# Altium schematic sheet PowerSubsystem.SchDoc
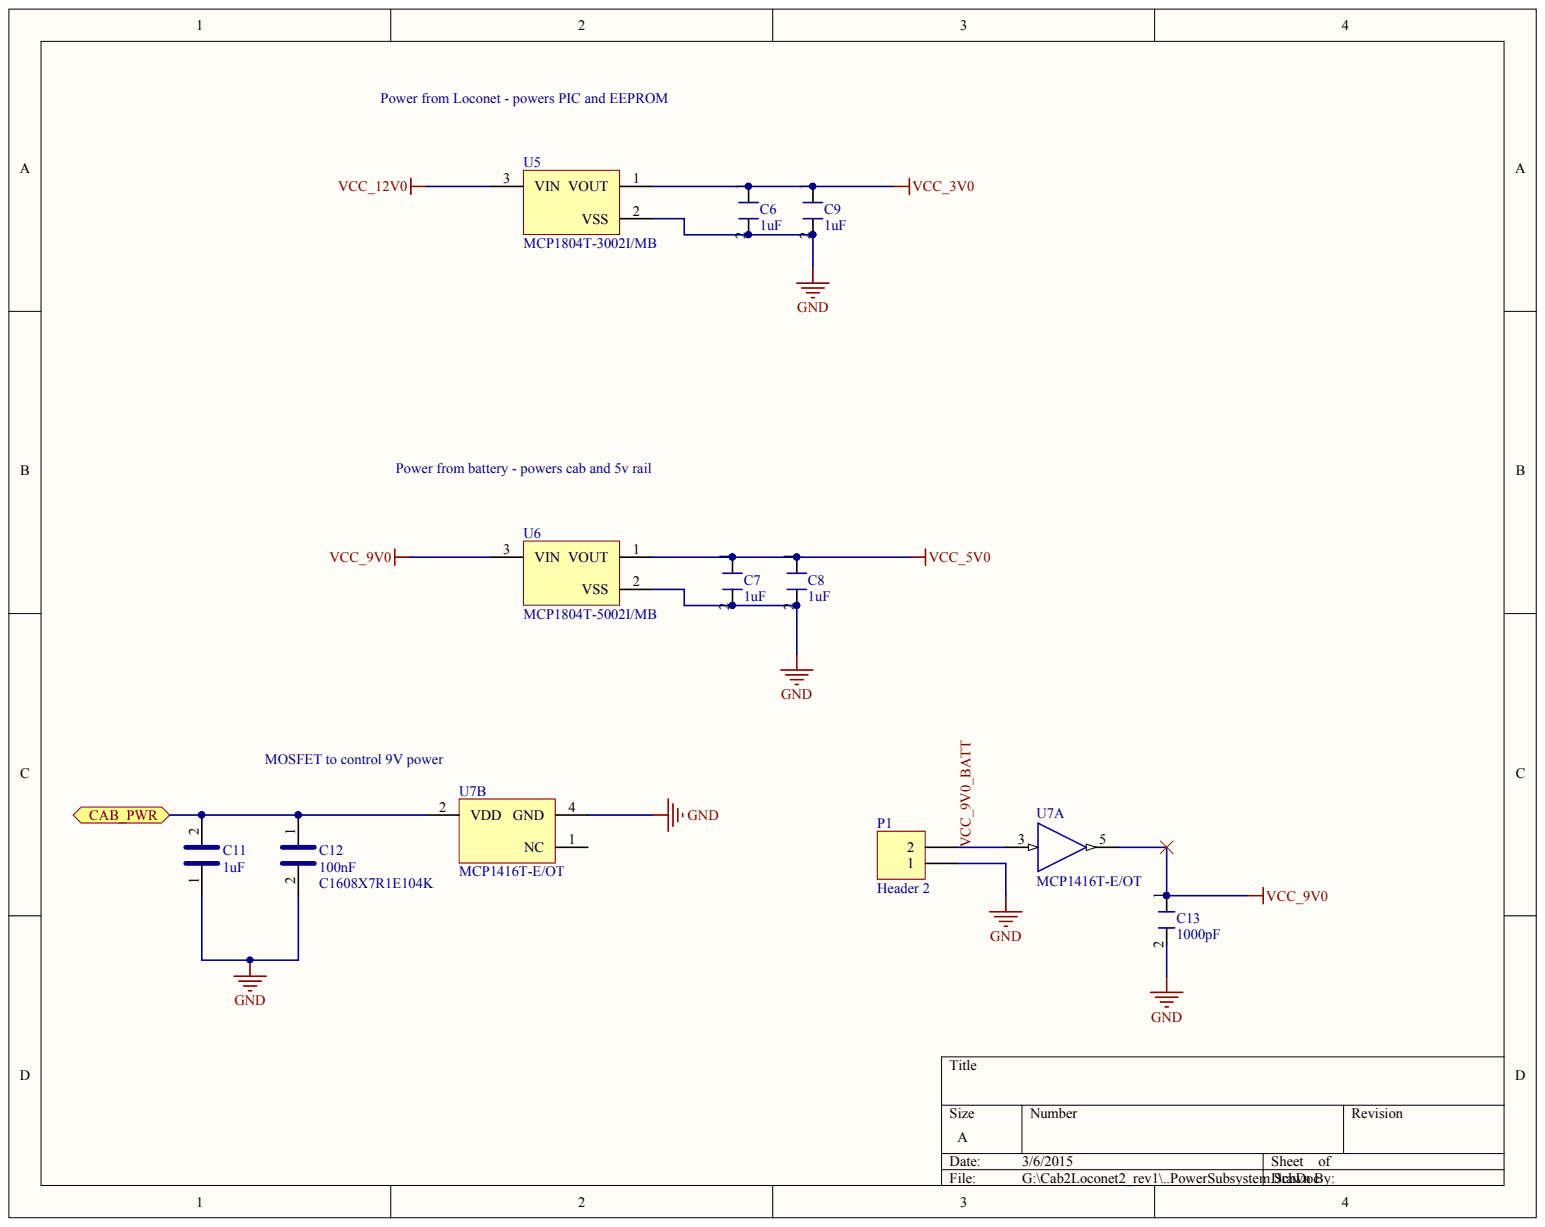
[diagram p0: PowerSubsystem.SchDoc]

## Parts
C6  1uF
C7  1uF
C8  1uF
C9  1uF
C11  1uF
C12  100nF  Manufacturer No: C1608X7R1E104K
C13  1000pF
P1  Header 2
U5  MCP1804T-3002I/MB
U6  MCP1804T-5002I/MB
U7  MCP1416T-E/OT  (parts A,B)

## Ports
CAB_PWR

## Nets
GND: C6.2 C7.2 C8.2 C9.2 C11.1 C12.2 C13.2 P1.1 U5.2 U6.2 U7.4(GND)
VCC_3V0: C6.1 C9.1 U5.1
VCC_5V0: C7.1 C8.1 U6.1
VCC_9V0: C13.1 U6.3 U7.5
VCC_12V0: U5.3
CAB_PWR: C11.2 C12.1 U7.2(VDD) ; port CAB_PWR
VCC_9V0_BATT: P1.2 U7.3

Not connected: U7 1(NC)

## Library data of the parts
C6-C9 C11 = (no symbol name): Manufacturer: TDK; Manufacturer No: C1608X7R1C105M; Supplier 1: Digi-Key; Supplier Part Number 1: 445-5131-2-ND
C12 = (no symbol name): Manufacturer: TDK; Supplier 1: Digi-Key; Supplier Part Number 1: 445-1316-2-ND
C13 = (no symbol name): Manufacturer: Yageo; Manufacturer No: CC0603JRNPO8BN102; Supplier 1: Digi-Key; Supplier Part Number 1: 311-1342-1-ND
U5-U7 = (no symbol name): Manufacturer: Microchip; PackageVersion: revBB, Aug-2009; Supplier 1: Digi-Key; Supplier 2: Digi-Key; Supplier 3: Digi-Key; Mounting Technology: Surface Mount; ComponentLink1Description: Manufacturer URL; ComponentLink1URL: http://www.microchip.com/; ComponentLink2Description: Datasheet; ComponentLink3Description: Package Specification; ComponentLink3URL: http://www.microchip.com/stellent/groups/techpub_sg/documents/packagingspec/en012702.pdf
  U5: DatasheetVersion: revB, Nov-2009; PackageReference: SOT-89-MB3; PackageDescription: 3-Lead Plastic Small Outline Transistor Header (MB) [SOT-89]; Supplier Part Number 1: MCP1804T-3002I/MBTR-ND; Supplier Part Number 2: MCP1804T-3002I/MBCT-ND; Supplier Part Number 3: MCP1804T-3002I/MBDKR-ND; Supplier 4: Mouser; Supplier Part Number 4: 579-MCP1804T-3002IMB; Code_IPC: SOT89; PartNumber: MCP1804T-3002I/MB; ComponentLink2URL: http://ww1.microchip.com/downloads/en/DeviceDoc/22200b.pdf
  U6: DatasheetVersion: revB, Nov-2009; PackageReference: SOT-89-MB3; PackageDescription: 3-Lead Plastic Small Outline Transistor Header (MB) [SOT-89]; Supplier Part Number 1: MCP1804T-5002I/MBTR-ND; Supplier Part Number 2: MCP1804T-5002I/MBCT-ND; Supplier Part Number 3: MCP1804T-5002I/MBDKR-ND; Supplier 4: Newark; Supplier Part Number 4: 52R9940; Supplier 5: Mouser; Supplier Part Number 5: 579-MCP1804T-5002IMB; Code_IPC: SOT89; PartNumber: MCP1804T-5002I/MB; ComponentLink2URL: http://ww1.microchip.com/downloads/en/DeviceDoc/22200b.pdf
  U7: DatasheetVersion: revC, Dec-2008; PackageReference: SOT-23-OT5; PackageDescription: 5-Lead Plastic Small Outline Transistor (OT) [SOT-23]; Supplier Part Number 1: MCP1416T-E/OTTR-ND; Supplier Part Number 2: MCP1416T-E/OTCT-ND; Supplier Part Number 3: MCP1416T-E/OTDKR-ND; Supplier 4: Farnell; Supplier Part Number 4: 1630421RL; Supplier 5: Farnell; Supplier Part Number 5: 1630421; Supplier 6: Newark; Supplier Part Number 6: 02P6620; Supplier 7: Newark; Supplier Part Number 7: 77M2548; Supplier 8: Mouser; Supplier Part Number 8: 579-MCP1416T-E/OT; Code_IPC: SOT23-5; PartNumber: MCP1416T-E/OT; ComponentLink2URL: http://ww1.microchip.com/downloads/en/DeviceDoc/22092c.pdf
P1 = (no symbol name): LatestRevisionDate: 17-Jul-2002; LatestRevisionNote: Re-released for DXP Platform.; Publisher: Altium Limited
